# Altium schematic sheet [03] - Power Supply.SchDoc("[03] - Power Supply")
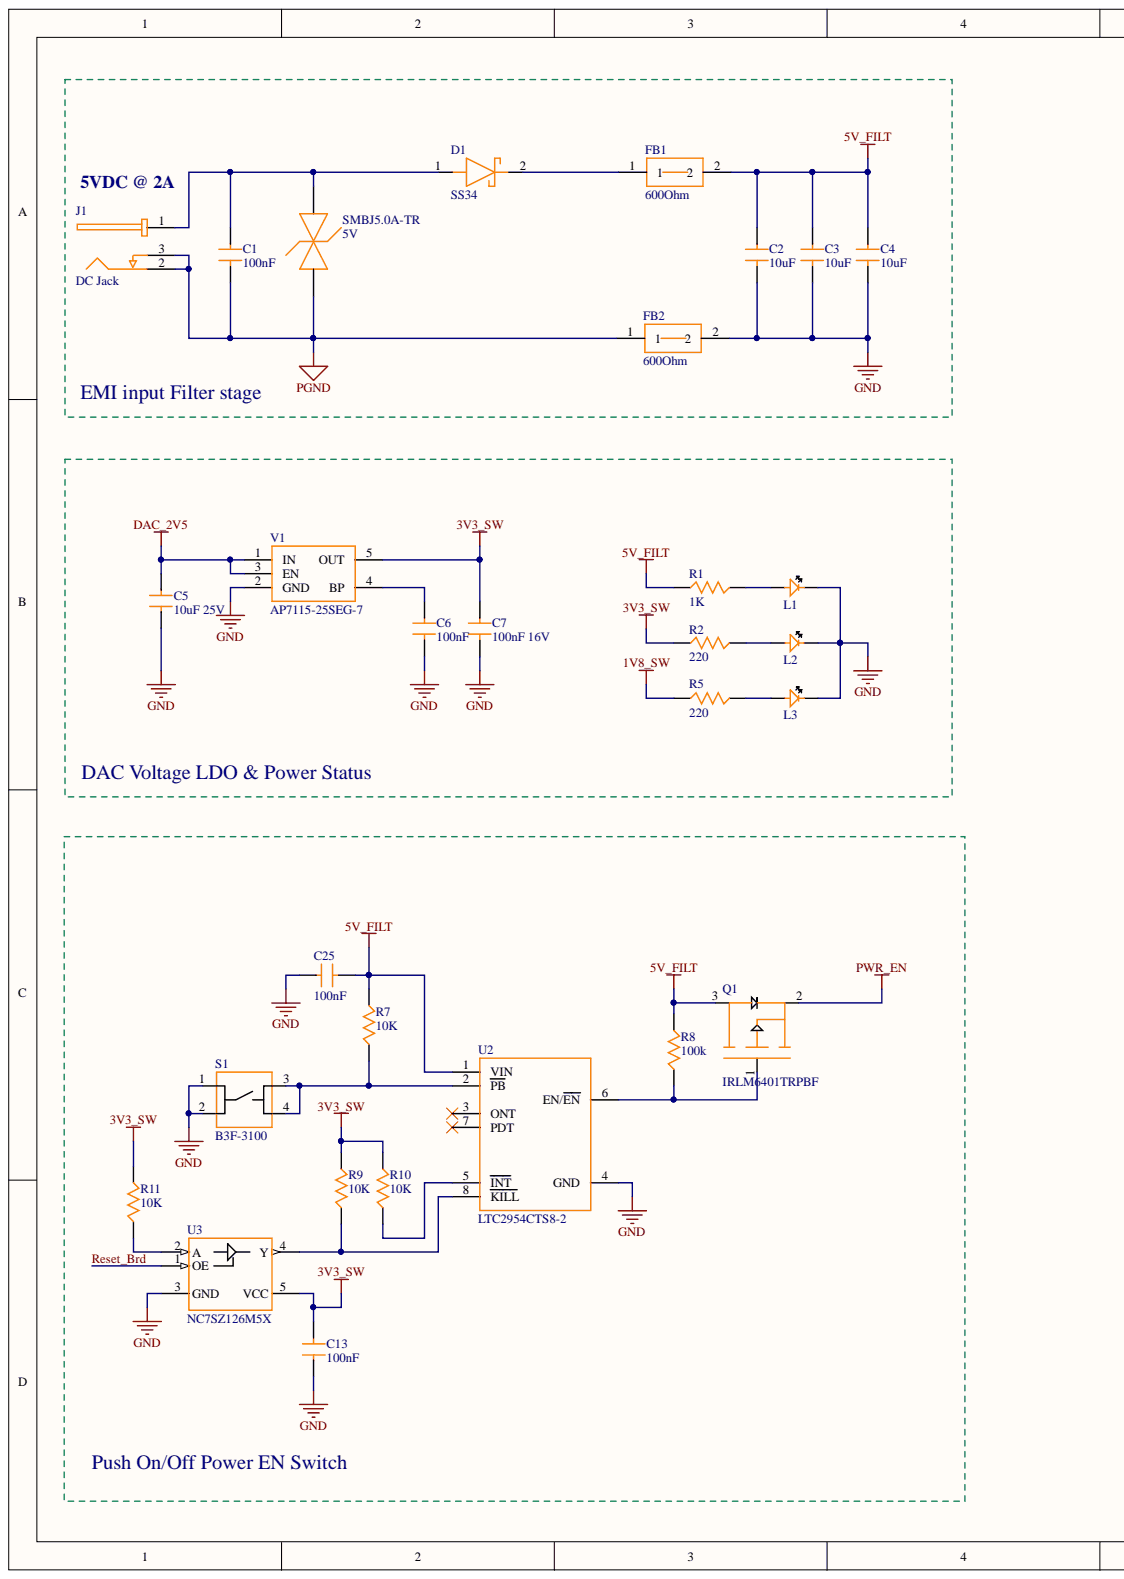
[diagram p0: [03] - Power Supply.SchDoc, left]
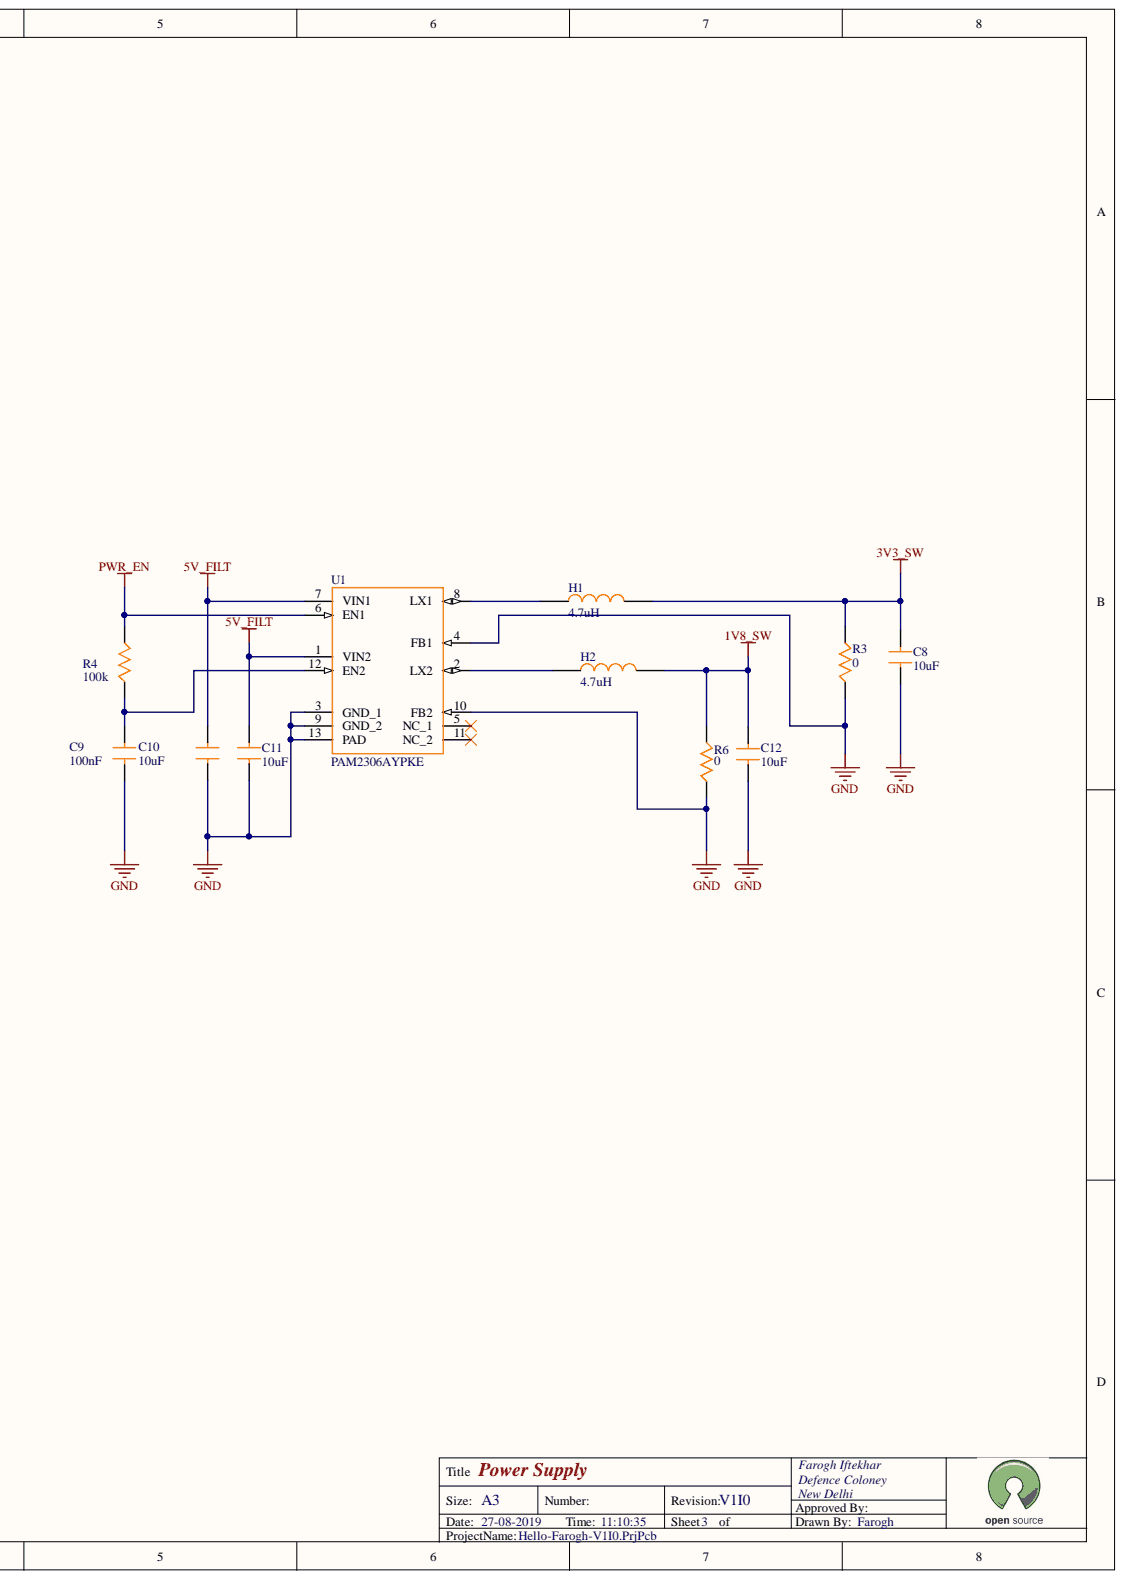
[diagram p1: [03] - Power Supply.SchDoc, right]

## Parts
C1  100nF  [C0805]
C2  10uF  [C1206]
C3  10uF  [C1206]
C4  10uF  [C1206]
C5  10uF 25V  [C0805]
C6  100nF  [C0805]
C7  100nF 16V  [C0805]
C8  10uF  [C0805]
C9  100nF  [C0805]
C10  10uF  [C0805]
C11  10uF  [C0805]
C12  10uF  [C0805]
C13  100nF  [C0805]
C25  100nF  [C0805]
D1  SS34  [SS34]
FB1  600Ohm  [FB_1210]
FB2  600Ohm  [FB_1210]
H1  4.7uH  [SRN4018]
H2  4.7uH  [SRN4018]
J1  DC Jack  Connector Type: Jack  [PJ-059BH]
L1  599-0130-007F  [LED0805]  (value not drawn)
L2  599-0130-007F  [LED0805]  (value not drawn)
L3  599-0130-007F  [LED0805]  (value not drawn)
Q1  IRLM6401TRPBF  [IRLML6401TRPBF]
R1  1K  [R0805]
R2  220  [R0805]
R3  0  [R0805]
R4  100k  [R0805]
R5  220  [R0805]
R6  0  [R0805]
R7  10K  [R0805]
R8  100k  [R0805]
R9  10K  [R0805]
R10  10K  [R0805]
R11  10K  [R0805]
S1  B3F-3100  [BSF-3100]
SMBJ5.0A-TR  5V  [SMBJ5.0A-TR]
U1  PAM2306AYPKE  [PAM2306AYPKE]
U2  LTC2954CTS8-2  [LTC2954CTS8-2]
U3  NC7SZ126M5X  RoHS - Mouser: Y  [NC7SZ126M5X]
V1  AP7115-25SEG-7  [AP7115-25SEG-7]

## Nets
1V8_SW: C12.2 H2.2 R5.1 R6.2
3V3_SW: C7.2 C8.2 C13.1 H1.2 R2.1 R3.2 R9.1 R10.2 R11.1 U3.5(VCC) V1.5(OUT)
5V_FILT: C2.2 C3.2 C4.2 C10.2 C11.2 C25.1 FB1.2 Q1.3 R1.1 R7.2 R8.2 U1.1(VIN2) U1.7(VIN1) U2.1(VIN)
DAC_2V5: C5.2 V1.1(IN) V1.3(EN)
GND: C2.1 C3.1 C4.1 C5.1 C6.1 C7.1 C8.1 C9.1 C10.1 C11.1 C12.1 C13.2 C25.2 FB2.2 L1.2 L2.2 L3.2 R3.1 R6.1 S1.1 S1.2 U1.3(GND_1) U1.4(FB1) U1.9(GND_2) U1.10(FB2) U1.13(PAD) U2.4(GND) U3.3(GND) V1.2(GND)
PGND: C1.1 FB2.1 J1.2 J1.3 SMBJ5.0A-TR.2
PWR_EN: Q1.2 R4.2 U1.6(EN1)
RESET_BRD: U3.1(OE)
NetC1_2: C1.2 D1.1 J1.1 SMBJ5.0A-TR.1
NetC6_2: C6.2 V1.4(BP)
NetC9_2: C9.2 R4.1 U1.12(EN2)
NetD1_2: D1.2 FB1.1
NetH1_1: H1.1 U1.8(LX1)
NetH2_1: H2.1 U1.2(LX2)
NetL1_1: L1.1 R1.2
NetL2_1: L2.1 R2.2
NetL3_1: L3.1 R5.2
NetQ1_1: Q1.1 R8.1 U2.6(EN/EN)
NetR7_1: R7.1 S1.3 S1.4 U2.2(PB)
NetR9_2: R9.2 U2.8(KILL) U3.4(Y)
NetR10_1: R10.1 U2.5(INT)
NetR11_2: R11.2 U3.2(A)

Not connected: U1 5(NC_1) 11(NC_2); U2 3(ONT) 7(PDT)

## Library data of the parts
C1 C6 C7 C9 C13 C25 = C_0805-100nF-16V: Multilayer Ceramic Capacitors MLCC - SMD/SMT 100nF 16V 20% Ceramic Capacitor; Manufacturer Part Number: CC0805MRX7R7BB104; Brand: Yageo; Capacitance: 0.1 uF; Capacitance - pF: 100000 pF; Class: Class 2; Dielectric: X7R; Product Status: New at Mouser; Type: General Purpose and High Capacitance Surface Mount Ceramic Multilayer Capacitor; Voltage Rating DC: 16 VDC; Capacitance - nF: 100 nF; Case Code - in: 0805; Case Code - mm: 2012; Category: Multilayer Ceramic Capacitors MLCC - SMD/SMT; Component Kind: Standard; ComponentLink1Description: https://www.mouser.com/datasheet/2/447/UPY-GPHC_X7R_6.3V-to-50V_18-1154002.pdf; ComponentLink1URL: https://www.mouser.com/datasheet/2/447/UPY-GPHC_X7R_6.3V-to-50V_18-1154002.pdf; Height: 0.85 mm; Length: 2 mm; Manufacturer: Yageo; Maximum Operating Temperature: + 125 C; Minimum Operating Temperature: - 55 C; Minimum Order Quantity: 1; Package / Case: 0805 (2012 metric); Packaging: Cut Tape; Pricing 1: 1=0.240, 10=0.114, 100=0.057, 1000=0.049, 4000=0.036, 8000=0.034, 24000=0.033, 48000=0.031, 100000=0.026 (USD); Product: General Type MLCCs; Product Type: Ceramic Capacitors; Reeling: True; Series: CC; Standard Pack Qty: 4000; Stock 1: 15850; Subcategory: Capacitors; Supplier 1: Mouser; Supplier Part Number 1: 603-CC0805MRX77BB104; Termination: Standard; Termination Style: SMD/SMT; Tolerance: 20 %; Width: 1.25 mm
  C13 C25: Library Name: Capacitor_0805.SchLib
  C1 C6 C7 C9: Library Name: Joule_Library.IntLib
C2-C4 = C_1206-10uF: Multilayer Ceramic Capacitors MLCC - SMD/SMT 10uF Y5V -20/+80% 50V; Manufacturer Part Number: CC1210ZKY5V9BB106; Brand: Yageo; Class: Class 2; Tolerance: - 20 %, + 80 %; Type: MLCC Surface Mount Ceramic Capacitor; Voltage Rating DC: 50 VDC; Capacitance: 10 uF; Case Code - in: 1210; Case Code - mm: 3225; Category: Multilayer Ceramic Capacitors MLCC - SMD/SMT; Component Kind: Standard; ComponentLink1Description: https://www.mouser.com/datasheet/2/447/UPY-GPHC_Y5V_6.3V-to-50V_8-1280790.pdf; ComponentLink1URL: https://www.mouser.com/datasheet/2/447/UPY-GPHC_Y5V_6.3V-to-50V_8-1280790.pdf; Dielectric: Y5V; Height: 1.5 mm; Length: 3.2 mm; Manufacturer: Yageo; Maximum Operating Temperature: + 85 C; Minimum Operating Temperature: - 30 C; Minimum Order Quantity: 1; Package / Case: 1210 (3225 metric); Packaging: Cut Tape; Pricing 1: 1=0.760, 10=0.524, 100=0.298, 500=0.287, 1000=0.279, 2000=0.270 (USD); Product: General Type MLCCs; Product Type: Ceramic Capacitors; Reeling: True; RoHS: RoHS Compliant; RoHS - Mouser: Y; Series: CC; Standard Pack Qty: 2000; Stock 1: 4065; Subcategory: Capacitors; Supplier 1: Mouser; Supplier Part Number 1: 603-CC121ZKY5V9BB106; Termination: Standard; Termination Style: SMD/SMT; Width: 2.5 mm; Library Name: Joule_Library.IntLib
C5 C8 C10-C12 = C_0805-10uF: Multilayer Ceramic Capacitors MLCC - SMD/SMT 10uF 10% 25V; Manufacturer Part Number: CC0805KFX5R8BB106; Brand: Yageo; Class: Class 2; CNHTS: 8532241000; Dielectric: X5R; Product Status: New Product; TARIC: 8532240000; Type: MLCC Surface Mount Ceramic Capacitor; Voltage Rating DC: 25 VDC; Capacitance: 10 uF; Case Code - in: 0805; Case Code - mm: 2012; Category: Multilayer Ceramic Capacitors MLCC - SMD/SMT; Component Kind: Standard; ComponentLink1Description: http://www.mouser.com/datasheet/2/447/UPY-GPHC_X5R_4V-to-50V_25-1131599.pdf; ComponentLink1URL: http://www.mouser.com/datasheet/2/447/UPY-GPHC_X5R_4V-to-50V_25-1131599.pdf; Height: 1.25 mm; HTS Code: 8532240020; Length: 2 mm; Manufacturer: Yageo; Maximum Operating Temperature: + 85 C; Minimum Operating Temperature: - 55 C; Minimum Order Quantity: 1; Package / Case: 0805 (2012 metric); Packaging: Cut Tape; Pricing 1: 1=0.550, 10=0.342, 100=0.175, 1000=0.158, 2500=0.110, 10000=0.106, 20000=0.103, 50000=0.096, 100000=0.089 (USD); Product: General Type MLCCs; Product Type: Ceramic Capacitors; Reeling: True; RoHS: RoHS Compliant; RoHS - Mouser: Y; Series: CC; Standard Pack Qty: 10000; Stock 1: 9112; Subcategory: Capacitors; Supplier 1: Mouser; Supplier Part Number 1: 603-CC0805KFX5R8BB10; Termination: Standard; Termination Style: SMD/SMT; Tolerance: 10 %; Width: 1.25 mm; Library Name: Joule_Library.IntLib
D1 = SS34: Schottky Diodes & Rectifiers 3a 40V Rectifier Schottky Barrier; Brand: ON Semiconductor / Fairchild; Configuration: Single; Ifsm - Forward Surge Current: 100 A; Ir - Reverse Current: 500 uA; Vf - Forward Voltage: 0.5 V; Category: Schottky Diodes & Rectifiers; Component Kind: Standard; ComponentLink1Description: https://www.mouser.com/datasheet/2/308/SS34-1307214.pdf; ComponentLink1URL: https://www.mouser.com/datasheet/2/308/SS34-1307214.pdf; Height: 2.45 mm (Max); If - Forward Current: 3 A; Length: 7.15 mm (Max); Manufacturer: ON Semiconductor / Fairchild; Manufacturer Part Number: SS34; Maximum Operating Temperature: + 150 C; Minimum Operating Temperature: - 55 C; Minimum Order Quantity: 1; Mounting Style: SMD/SMT; Operating Temperature Range: - 55 C to + 150 C; Package / Case: SMC (DO-214AB); Packaging: Cut Tape; Pricing 1: 1=0.610, 10=0.504, 100=0.307, 1000=0.238, 3000=0.203 (USD); Product: Schottky Rectifiers; Product Type: Schottky Diodes & Rectifiers; Reeling: True; RoHS: RoHS Compliant; RoHS - Mouser: Y; Series: SS34; Standard Pack Qty: 3000; Stock 1: 43909; Subcategory: Diodes & Rectifiers; Supplier 1: Mouser; Supplier Part Number 1: 512-SS34; Technology: Si; Type: Schottky Diode; Vrrm - Repetitive Reverse Voltage: 40 V; Width: 6.25 mm (Max); Library Name: Joule_Library.IntLib
FB1 FB2 = FB_1210-600, 3000mA: Ferrite Beads HI CUR CHIP BD 1210 600OHMS 30%; Manufacturer Part Number: FBMH3225HM601NT; Brand: Taiyo Yuden; Maximum DC Current: 3 A; Maximum DC Resistance: 42 mOhms; Test Frequency: 100 MHz; Type: Chip Bead Inductor for Power Lines; Capacitance: -; Category: Ferrite Beads; Component Kind: Standard; ComponentLink1Description: https://www.mouser.com/datasheet/2/396/TaiyoYuden_chipbeads01_e-1274227.pdf; ComponentLink1URL: https://www.mouser.com/datasheet/2/396/TaiyoYuden_chipbeads01_e-1274227.pdf; Height: 2.5 mm; Impedance: 600 Ohms; Length: 3.2 mm; Manufacturer: Taiyo Yuden; Maximum Operating Temperature: + 125 C; Minimum Operating Temperature: - 40 C; Minimum Order Quantity: 1; Operating Temperature Range: - 40 C to + 125 C; Package / Case: 1210 (3225 metric); Packaging: Cut Tape; Pricing 1: 1=0.280, 10=0.178, 100=0.158, 250=0.142, 500=0.130, 1000=0.100, 2000=0.097, 5000=0.093, 10000=0.090 (USD); Product: Ferrite Chip Beads; Product Type: Ferrite Beads; Reeling: True; RoHS: RoHS Compliant; RoHS - Mouser: Y; Series: FB; Standard Pack Qty: 1000; Stock 1: 19251; Subcategory: Ferrites; Supplier 1: Mouser; Supplier Part Number 1: 963-FBMH3225HM601NT; Termination Style: SMD/SMT; Tolerance: 30 %; Width: 2.5 mm; Library Name: Joule_Library.IntLib
H1 H2 = SRN4018-4R7M: Fixed Inductors 4.7uH 20% SMD 4018; Brand: Bourns; CNHTS: 8504500000; Core Material: Ferrite; Maximum DC Current: 1.9 A; Maximum DC Resistance: 84 mOhms; MXHTS: 85045002; Shielding: Shielded; TARIC: 8504509590; Test Frequency: 100 kHz; Application: Power; Category: Fixed Inductors; Component Kind: Standard; ComponentLink1Description: http://www.mouser.com/datasheet/2/54/rn4018-1391320.pdf; ComponentLink1URL: http://www.mouser.com/datasheet/2/54/rn4018-1391320.pdf; Height: 1.8 mm; HTS Code: 8504508000; Inductance: 4.7 uH; Length: 4 mm; Manufacturer: Bourns; Manufacturer Part Number: SRN4018-4R7M; Maximum Operating Temperature: + 120 C; Minimum Operating Temperature: - 25 C; Minimum Order Quantity: 1; Mounting Style: PCB Mount; Packaging: Cut Tape; Pricing 1: 1=0.360, 10=0.246, 100=0.224, 250=0.210, 500=0.188, 1000=0.152, 3000=0.133, 6000=0.132, 9000=0.131 (USD); Product: Fixed Inductors; Product Type: Fixed Inductors; Reeling: True; RoHS: RoHS Compliant; RoHS - Mouser: Y; Series: SRN4018; Standard Pack Qty: 3000; Stock 1: 24604; Subcategory: Inductors, Chokes & Coils; Supplier 1: Mouser; Supplier Part Number 1: 652-SRN4018-4R7M; Termination: -; Termination Style: SMD/SMT; Tolerance: 20 %; Width: 4 mm; Library Name: Joule_Library.IntLib
J1 = DC-PJ-059BH: DC Power Connectors power jack, 2.5 x 5.5 mm, horizontal, through hole, high current, 1 switch; Brand: CUI; Current Rating: 5 A; Inside Contact Diameter: 2.5 mm; Insulation Resistance: 100 MOhms; Mounting Angle: Right Angle; Outside Contact Diameter: 5.7 mm; Voltage Rating: 24 V; Category: DC Power Connectors; Color: Black; Component Kind: Standard; ComponentLink1Description: https://www.mouser.com/datasheet/2/670/pj-059bh-1312730.pdf; ComponentLink1URL: https://www.mouser.com/datasheet/2/670/pj-059bh-1312730.pdf; Contact Gender: Pin (Male); Contact Material: Copper; Contact Plating: Nickel; Flammability Rating: UL 94 V-0; Housing Material: Polybutylene Terephthalate (PBT); Manufacturer: CUI; Manufacturer Part Number: PJ-059BH; Maximum Operating Temperature: + 85 C; Minimum Operating Temperature: - 25 C; Minimum Order Quantity: 1; Mounting Style: Panel; Packaging: Tray; Pricing 1: 1=0.890, 10=0.785, 25=0.739, 50=0.708, 100=0.677, 250=0.616, 500=0.554, 1000=0.492, 2500=0.446 (USD); Product: Jacks; Product Type: DC Power Connectors; Reeling: False; RoHS: RoHS Compliant; RoHS - Mouser: Y; Standard Pack Qty: 280; Stock 1: 122; Subcategory: Power Connectors; Supplier 1: Mouser; Termination Style: Through Hole; Library Name: Joule_Library.IntLib
L1-L3 = 599-0130-007F: Standard LEDs - SMD SMD 0805 ORANGE; Brand: Dialight; CNHTS: 8541401000; If - Forward Current: 20 mA; Lens Color/Style: Clear; Luminous Intensity: 95 mcd; Product Status: New Product; Vf - Forward Voltage: 2.1 V; Viewing Angle: 140 deg; Wavelength/Color Temperature: 605 nm; Category: Standard LEDs - SMD; Component Kind: Standard; ComponentLink1Description: http://www.mouser.com/datasheet/2/109/Dialight_CBI_data_599-0805_Apr2018-1370606.pdf; ComponentLink1URL: http://www.mouser.com/datasheet/2/109/Dialight_CBI_data_599-0805_Apr2018-1370606.pdf; Height: 0.7 mm; HTS Code: 8541402000; Illumination Color: Orange; Length: 2 mm; Manufacturer: Dialight; Manufacturer Part Number: 599-0130-007F; Maximum Operating Temperature: + 100 C; Minimum Operating Temperature: - 40 C; Minimum Order Quantity: 1; Mounting Style: SMD/SMT; Orientation: Top View; Package / Case: 0805 (2012 metric); Packaging: Cut Tape; Pricing 1: 1=0.450, 10=0.264, 100=0.106, 1000=0.083, 2500=0.060, 4000=0.060, 8000=0.053, 24000=0.050 (USD); Product: Orange LEDs; Product Type: LED - Standard; Reeling: True; RoHS: RoHS Compliant; RoHS - Mouser: Y; Standard Pack Qty: 4000; Stock 1: 15445; Subcategory: LEDs; Supplier 1: Mouser; Supplier Part Number 1: 645-599-0130-007F; Width: 1.25 mm; Library Name: Joule_Library.IntLib
Q1 = IRLML6401TRPBF: MOSFET MOSFT P-Ch -4.3A 50mOhm 10nC Log Lvl; Brand: Infineon Technologies; Configuration: Single; Id - Continuous Drain Current: 4.3 A; Number of Channels: 1 Channel; Pd - Power Dissipation: 1.3 W; Rds On - Drain-Source Resistance: 50 mOhms; Transistor Polarity: P-Channel; Transistor Type: 1 P-Channel; Vds - Drain-Source Breakdown Voltage: 12 V; Vgs - Gate-Source Voltage: 8 V; Category: MOSFET; Component Kind: Standard; ComponentLink1Description: https://www.mouser.com/datasheet/2/196/irlml6401pbf-1228277.pdf; ComponentLink1URL: https://www.mouser.com/datasheet/2/196/irlml6401pbf-1228277.pdf; Height: 1.1 mm; Length: 2.9 mm; Manufacturer: Infineon Technologies; Manufacturer Part Number: IRLML6401TRPBF; Minimum Order Quantity: 1; Mounting Style: SMD/SMT; Package / Case: SOT-23-3; Packaging: Cut Tape; Pricing 1: 1=0.420, 10=0.327, 100=0.178, 1000=0.133, 3000=0.115, 9000=0.108 (USD); Product Type: MOSFET; Qg - Gate Charge: 10 nC; Reeling: True; RoHS: RoHS Compliant; RoHS - Mouser: Y; Standard Pack Qty: 3000; Stock 1: 70377; Subcategory: MOSFETs; Supplier 1: Mouser; Supplier Part Number 1: 942-IRLML6401TRPBF; Technology: Si; Width: 1.3 mm; Library Name: Joule_Library.IntLib
R1 = R_0805-1K: Thin Film Resistors - SMD 1K ohm 1% 50 ppm Thin Film; Manufacturer Part Number: RT0805FRE101KL; Brand: Yageo; CNHTS: 8533211000; MXHTS: 85332101; Power Rating: 125 mW (1/8 W); Product Status: New Product; Resistance: 1 kOhms; TARIC: 8533210000; Temperature Coefficient: 50 PPM / C; Voltage Rating: 150 V; Case Code - in: 0805; Case Code - mm: 2012; Category: Thin Film Resistors - SMD; Component Kind: Standard; ComponentLink1Description: http://www.mouser.com/datasheet/2/447/PYu-RT_1-to-0.01_RoHS_L_9-1222720.pdf; ComponentLink1URL: http://www.mouser.com/datasheet/2/447/PYu-RT_1-to-0.01_RoHS_L_9-1222720.pdf; Features: -; Height: 0.5 mm; HTS Code: 8533210030; Length: 2 mm; Manufacturer: Yageo; Maximum Operating Temperature: + 155 C; Minimum Operating Temperature: - 55 C; Minimum Order Quantity: 1; Package / Case: 0805 (2012 metric); Packaging: Cut Tape; Pricing 1: 1=0.120, 10=0.064, 100=0.023, 1000=0.016, 2500=0.011, 10000=0.010, 50000=0.009 (USD); Product: Precision Resistors Thin Film SMD; Reeling: True; RoHS: RoHS Compliant; RoHS - Mouser: Y; Series: RT; Standard Pack Qty: 10000; Stock 1: 18694; Supplier 1: Mouser; Supplier Part Number 1: 603-RT0805FRE101KL; Termination Style: SMD/SMT; Tolerance: 1 %; Type: High Stability Thin Film Chip Resistor; Width: 1.25 mm; Library Name: Joule_Library.IntLib
R2 R5 = R_0805-220: Thin Film Resistors - SMD; Manufacturer Part Number: RT0805DRE07220RL; Brand: Yageo; CNHTS: 8533211000; MXHTS: 85332101; Power Rating: 125 mW (1/8 W); Resistance: 220 Ohms; TARIC: 8533210000; Temperature Coefficient: 50 PPM / C; Tolerance: 0.5 %; Voltage Rating: 150 V; Case Code - in: 0805; Case Code - mm: 2012; Category: Thin Film Resistors - SMD; Component Kind: Standard; ComponentLink1Description: https://www.mouser.com/datasheet/2/447/PYu-RT_1-to-0.01_RoHS_L_9-1222720.pdf; ComponentLink1URL: https://www.mouser.com/datasheet/2/447/PYu-RT_1-to-0.01_RoHS_L_9-1222720.pdf; Features: -; Height: 0.5 mm; HTS Code: 8533210030; Length: 2 mm; Manufacturer: Yageo; Maximum Operating Temperature: + 125 C; Minimum Operating Temperature: - 55 C; Minimum Order Quantity: 1; Mounting Style: PCB Mount; Operating Temperature Range: - 55 C to + 125 C; Packaging: Cut Tape; Pricing 1: 1=0.170, 10=0.088, 100=0.031, 1000=0.022, 5000=0.015, 10000=0.014, 25000=0.013, 50000=0.012 (USD); Product: Thin Film Resistors SMD; Product Type: Thin Film Resistors; Reeling: True; RoHS: RoHS Compliant; RoHS - Mouser: Y; Series: RT; Standard Pack Qty: 5000; Stock 1: 14351; Subcategory: Resistors; Supplier 1: Mouser; Supplier Part Number 1: 603-RT0805DRE07220RL; Technology: Thin Film; Termination Style: SMD/SMT; Type: High Precision, High Stability; Width: 1.2 mm; Library Name: Joule_Library.IntLib
R3 R6 = R_0805-0: Current Sense Resistors - SMD 0 ohm Jumper 1/2W; Manufacturer Part Number: PA0805-R-470RL; Brand: Yageo; CNHTS: 8533211000; Power Rating: 500 mW (1/2 W); Product Status: New Product; Resistance: 0 Ohms; TARIC: 8533210000; Application: Current Sense; Case Code - in: 0805; Case Code - mm: 2012; Category: Current Sense Resistors - SMD; Component Kind: Standard; ComponentLink1Description: http://www.mouser.com/datasheet/2/447/PYu-PA0805_L_51_RoHS_0-1480254.pdf; ComponentLink1URL: http://www.mouser.com/datasheet/2/447/PYu-PA0805_L_51_RoHS_0-1480254.pdf; HTS Code: 8533210030; Length: 2.03 mm; Manufacturer: Yageo; Maximum Operating Temperature: + 155 C; Minimum Operating Temperature: - 55 C; Minimum Order Quantity: 1; Mounting Style: PCB Mount; Packaging: Cut Tape; Pricing 1: 1=1.30, 10=1.06, 100=0.706, 500=0.595, 1000=0.495, 5000=0.431, 10000=0.421, 25000=0.409 (USD); Product: Current Sense Chip Resistor Surface Mount; Product Type: Current Sense Resistors; Reeling: True; RoHS: RoHS Compliant; RoHS - Mouser: Y; Series: PA_L; Standard Pack Qty: 5000; Stock 1: 3927; Subcategory: Resistors; Supplier 1: Mouser; Supplier Part Number 1: 603-PA0805-R-470RL; Technology: Metal Element; Termination: 2 Terminal; Termination Style: SMD/SMT; Tolerance: -; Type: Low TCR Current Sense Resistor; Width: 1.27 mm; Library Name: Joule_Library.IntLib
R4 R8 = R_0805-100K: Thick Film Resistors - SMD 100K ohm 1% 150V AEC-Q200; Manufacturer Part Number: AC0805FR-13100KL; Brand: Yageo; Power Rating: 125 mW (1/8 W); Product Status: New Product; Resistance: 100 kOhms; Temperature Coefficient: 100 PPM / C; Application: Automotive Grade; Case Code - in: 0805; Case Code - mm: 2012; Category: Thick Film Resistors - SMD; Component Kind: Standard; ComponentLink1Description: http://www.mouser.com/datasheet/2/447/PYu-AC_51_RoHS_L_6-1152827.pdf; ComponentLink1URL: http://www.mouser.com/datasheet/2/447/PYu-AC_51_RoHS_L_6-1152827.pdf; Features: -; Height: 0.5 mm; HTS Code: 8533210030; Length: 2 mm; Manufacturer: Yageo; Maximum Operating Temperature: + 155 C; Minimum Operating Temperature: - 55 C; Minimum Order Quantity: 1; Mounting Style: PCB Mount; Package / Case: 0805 (2012 metric); Packaging: Cut Tape; Pricing 1: 1=0.160, 10=0.037, 100=0.013, 1000=0.010, 2500=0.006, 20000=0.005 (USD); Product: Thick Film Resistors SMD; Product Type: Thick Film Resistors; Reeling: True; Series: AC; Standard Pack Qty: 20000; Stock 1: 38013; Subcategory: Resistors; Supplier 1: Mouser; Supplier Part Number 1: 603-AC0805FR-13100KL; Technology: Thick Film; Termination Style: SMD/SMT; Tolerance: 1 %; Voltage Rating: 150 V; Width: 1.25 mm; Library Name: Joule_Library.IntLib
R7 R9-R11 = R_0805-10K: Thick Film Resistors - SMD 10K   OHM   1% 1/4W; Manufacturer Part Number: RC0805FR-7W10KL; Brand: Yageo; CNHTS: 8533211000; MXHTS: 85332101; Power Rating: 125 mW, 250 mW; Resistance: 10 kOhms; TARIC: 8533210000; Temperature Coefficient: 100 PPM / C; Voltage Rating: 150 V; Application: High Reliability; Case Code - in: 0805; Case Code - mm: 2012; Category: Thick Film Resistors - SMD; Component Kind: Standard; ComponentLink1Description: http://www.mouser.com/datasheet/2/447/yageo_01152019_DESIGN_KITS_8_2012-1522572.pdf; ComponentLink1URL: http://www.mouser.com/datasheet/2/447/yageo_01152019_DESIGN_KITS_8_2012-1522572.pdf; Features: -; Height: 0.5 mm; HTS Code: 8533210030; Length: 2 mm; Manufacturer: Yageo; Maximum Operating Temperature: + 155 C; Minimum Operating Temperature: - 55 C; Minimum Order Quantity: 1; Mounting Style: PCB Mount; Package / Case: 0805 (2012 metric); Packaging: Cut Tape; Pricing 1: 1=0.190, 10=0.115, 100=0.038, 1000=0.026, 5000=0.020, 10000=0.019, 25000=0.018, 100000=0.017 (USD); Product: Thick Film Resistors SMD; Product Type: Thick Film Resistors; Reeling: True; RoHS: RoHS Compliant; RoHS - Mouser: Y; Series: RC; Standard Pack Qty: 5000; Stock 1: 8240; Subcategory: Resistors; Supplier 1: Mouser; Supplier Part Number 1: 603-RC0805FR-7W10KL; Technology: Thick Film; Termination Style: SMD/SMT; Tolerance: 1 %; Type: High Power; Width: 1.25 mm; Library Name: Joule_Library.IntLib
S1 = B3F-3100: Tactile Switches 3.15mm VERT 100gF; Actuator: Round; Brand: Omron Electronics; CNHTS: 8536500000; Contact Form: SPST; Current Rating: 50 mA; Ground Terminal: Yes; Illuminated: Non-Illuminated; Mounting Direction: Right Angle; MXHTS: 85365099; Operating Force: 1 N; Voltage Rating DC: 24 VDC; Category: Tactile Switches; Color: Gray; Component Kind: Standard; ComponentLink1Description: http://www.mouser.com/datasheet/2/307/en-b3f-13826.pdf; ComponentLink1URL: http://www.mouser.com/datasheet/2/307/en-b3f-13826.pdf; Contact Plating: Gold; Height: 7.4 mm; HTS Code: 8536509065; Illumination Color: -; Lamp Type: -; Length: 6.25 mm; Manufacturer: Omron Electronics; Manufacturer Part Number: B3F-3100; Maximum Operating Temperature: + 70 C; Minimum Operating Temperature: - 25 C; Minimum Order Quantity: 1; Mounting Style: Through Hole; Pricing 1: 1=0.470, 10=0.452, 25=0.444, 50=0.427, 100=0.369, 250=0.335, 500=0.319, 1000=0.252, 2500=0.235 (USD); Product Type: Tactile Switches; Reeling: False; RoHS: RoHS Compliant; RoHS - Mouser: Y; Series: B3F; Standard Pack Qty: 1000; Stem Height: 3.15 mm; Stock 1: 5962; Subcategory: Switches; Supplier 1: Mouser; Supplier Part Number 1: 653-B3F-3100; Termination Style: Solder Pin; Voltage Rating AC: -; Width: 7.3 mm; Library Name: Joule_Library.IntLib
SMBJ5.0A-TR = SMBJ5.0A-TR: ESD Suppressors / TVS Diodes 600W 5.0V Unidirect; Brand: STMicroelectronics; Breakdown Voltage: 6.4 V to 7.07 V; Clamping Voltage: 13.4 V; Polarity: Unidirectional; Category: ESD Suppressors / TVS Diodes; Component Kind: Standard; ComponentLink1Description: https://www.mouser.com/datasheet/2/389/smbj-955195.pdf; ComponentLink1URL: https://www.mouser.com/datasheet/2/389/smbj-955195.pdf; Manufacturer: STMicroelectronics; Manufacturer Part Number: SMBJ5.0A-TR; Maximum Operating Temperature: + 175 C; Minimum Operating Temperature: - 65 C; Minimum Order Quantity: 1; Package / Case: DO-214AA-2 (SMB); Packaging: Cut Tape; Pd - Power Dissipation: 600 W; Pricing 1: 1=0.360, 10=0.268, 100=0.146, 1000=0.109, 2500=0.094, 10000=0.088, 25000=0.081, 50000=0.078, 100000=0.075 (USD); Product Type: TVS Diodes; Reeling: True; RoHS: RoHS Compliant; RoHS - Mouser: Y; Series: SMBJ; Standard Pack Qty: 2500; Stock 1: 38599; Subcategory: TVS Diodes / ESD Suppression Diodes; Supplier 1: Mouser; Supplier Part Number 1: 511-SMBJ5.0A; Termination Style: SMD/SMT; Tradename: Transil; Vesd - Voltage ESD Air Gap: -; Vesd - Voltage ESD Contact: -; Working Voltage: 5 V; Library Name: Joule_Library.IntLib
U1 = PAM2306AYPKE: Switching Voltage Regulators Dual PWM Step-Down 40uA 1A 1.5MHz; Brand: Diodes Incorporated; Input Voltage: 2.5 V to 5.5 V; Input Voltage MAX: 5.5 V; Input Voltage MIN: 2.5 V; Load Regulation: 0.5 %; Number of Outputs: 2 Output; Output Voltage: 1.8 V, 3.3 V; Topology: Buck; Category: Switching Voltage Regulators; Component Kind: Standard; ComponentLink1Description: https://www.mouser.com/datasheet/2/115/PAM2306-336770.pdf; ComponentLink1URL: https://www.mouser.com/datasheet/2/115/PAM2306-336770.pdf; Library Name: ICs.SchLib; Manufacturer: Diodes Incorporated; Manufacturer Part Number: PAM2306AYPKE; Maximum Operating Temperature: + 85 C; Minimum Operating Temperature: - 40 C; Minimum Order Quantity: 1; Mounting Style: SMD/SMT; Operating Supply Current: 40 uA; Operating Temperature Range: - 40 C to + 85 C; Output Current: 1 A; Package / Case: WDFN-EP-12; Packaging: Cut Tape; Pricing 1: 1=0.700, 10=0.589, 100=0.380, 1000=0.304, 3000=0.304 (USD); Product Type: Switching Voltage Regulators; Reeling: True; RoHS: RoHS Compliant; RoHS - Mouser: Y; Series: PAM2306; Shutdown: Shutdown; Standard Pack Qty: 3000; Stock 1: 14729; Subcategory: PMIC - Power Management ICs; Supplier 1: Mouser; Supplier Part Number 1: 621-PAM2306AYPKE; Supply Voltage - Min: 2.5 V; Switching Frequency: 1.5 MHz; Tradename: PAM2306; Type: PWM Step-Down DC-DC Converter
U2 = LTC2954CTS8-2: Supervisory Circuits Push Button On/Off Controller with U Interrupt for Menu Driven Applications; Manufacturer Part Number: LTC2954CTS8-2#TRMPBF; Battery Backup Switching: No Backup; Brand: Analog Devices; Chip Enable Signals: Chip Enable; Development Kit: DC1090A; Number of Inputs Monitored: 1 Input; Operating Supply Current: 0.006 mA; Output Type: Open Drain; Power Fail Detection: Yes; Product Status: New at Mouser; Quiescent Current: 6 uA; Reset Delay Time: 43.5 ms; Supply Voltage - Max: 26.4 V; Supply Voltage - Min: 2.7 V; Threshold Voltage: 600 mV; Watchdog Timers: No Watchdog; Category: Supervisory Circuits; Component Kind: Standard; ComponentLink1Description: https://www.mouser.com/datasheet/2/609/2954fb-1267553.pdf; ComponentLink1URL: https://www.mouser.com/datasheet/2/609/2954fb-1267553.pdf; Manual Reset: Manual Reset; Manufacturer: Analog Devices; Maximum Operating Temperature: + 70 C; Minimum Operating Temperature: 0 C; Minimum Order Quantity: 1; Mounting Style: SMD/SMT; Package / Case: SOT-8; Packaging: Cut Tape; Pricing 1: 1=4.56, 25=3.03, 100=2.50, 250=2.37, 500=2.17, 1500=2.11 (USD); Product Type: Supervisory Circuits; Reeling: True; RoHS: RoHS Compliant; RoHS - Mouser: Y; Series: LTC2954; Standard Pack Qty: 500; Stock 1: 998; Subcategory: PMIC - Power Management ICs; Supplier 1: Mouser; Supplier Part Number 1: 584-C2954CTS8-2TMPF; Type: Voltage Supervisory; Library Name: Joule_Library.IntLib
U3 = NC7SZ126M5X: Buffers & Line Drivers UHS Buffer; Brand: ON Semiconductor / Fairchild; Function: Buffer/Line Driver; High Level Output Current: - 32 mA; Input Signal Type: Single-Ended; Logic Family: TinyLogic UHS; Logic Type: CMOS; Low Level Output Current: 32 mA; Number of Channels: 1 Channel; Number of Input Lines: 1 Input; Number of Output Lines: 1 Output; Operating Supply Voltage: 1.65 V to 5.5 V; Output Type: 3-State; Pd - Power Dissipation: 200 mW; Polarity: Non-Inverting; Propagation Delay Time: 5.7 ns at 3.3 V, 5 ns at 5 V; Quiescent Current: 2 uA; Supply Voltage - Max: 5.5 V; Supply Voltage - Min: 1.65 V; Category: Buffers & Line Drivers; Component Kind: Standard; ComponentLink1Description: https://www.mouser.com/datasheet/2/308/NC7SZ126-1301534.pdf; ComponentLink1URL: https://www.mouser.com/datasheet/2/308/NC7SZ126-1301534.pdf; Height: 1.3 mm (Max); Length: 3 mm (Max); Manufacturer: ON Semiconductor / Fairchild; Manufacturer Part Number: NC7SZ126M5X; Maximum Operating Temperature: + 85 C; Minimum Operating Temperature: - 40 C; Minimum Order Quantity: 1; Mounting Style: SMD/SMT; Package / Case: SOT-23-5; Packaging: Cut Tape; Pricing 1: 1=0.490, 10=0.314, 100=0.135, 1000=0.104, 3000=0.079, 9000=0.070, 24000=0.066 (USD); Product Type: Buffers & Line Drivers; Reeling: True; RoHS: RoHS Compliant; Series: NC7SZ126; Standard Pack Qty: 3000; Stock 1: 19641; Subcategory: Logic ICs; Supplier 1: Mouser; Supplier Part Number 1: 512-NC7SZ126M5X; Supply Current - Max: 2 uA; Technology: CMOS; Tradename: TinyLogic; Width: 1.7 mm (Max); Library Name: Joule_Library.IntLib
V1 = AP7115-25SEG-7: LDO Voltage Regulators LDO SNGLE OUTPUT 150MA 220MV 2.5-5.5V; Brand: Diodes Incorporated; Dropout Voltage - Max: 200 mV; Ib - Input Bias Current: 80 uA; Input Voltage MAX: 5.5 V; Input Voltage MIN: 2.5 V; Line Regulation: 0.1 %/V; Load Regulation: 0.003 %/mA; Number of Outputs: 1 Output; Output Type: Fixed; Pd - Power Dissipation: 500 mW (1/2 W); PSRR / Ripple Rejection - Typ: 70 dB; Voltage Regulation Accuracy: 2 %; Category: LDO Voltage Regulators; Component Kind: Standard; ComponentLink1Description: https://www.mouser.com/datasheet/2/115/AP7115-69794.pdf; ComponentLink1URL: https://www.mouser.com/datasheet/2/115/AP7115-69794.pdf; Height: 1.1 mm; Length: 3 mm; Library Name: Regulator.SchLib; Manufacturer: Diodes Incorporated; Manufacturer Part Number: AP7115-25SEG-7; Maximum Operating Temperature: + 85 C; Minimum Operating Temperature: - 40 C; Minimum Order Quantity: 1; Mounting Style: SMD/SMT; Operating Temperature Range: - 40 C to + 85 C; Output Current: 150 mA; Output Voltage: 2.5 V; Package / Case: SOT-353-5; Packaging: Cut Tape; Pricing 1: 1=0.380, 10=0.288, 100=0.156, 1000=0.117, 3000=0.101, 9000=0.095 (USD); Product: LDO Regulators; Product Type: LDO Voltage Regulators; Reeling: True; RoHS: RoHS Compliant; RoHS - Mouser: Y; Series: AP7115; Standard Pack Qty: 3000; Stock 1: 7418; Subcategory: PMIC - Power Management ICs; Supplier 1: Mouser; Supplier Part Number 1: 621-AP7115-25SEG-7; Width: 1.6 mm
